# Altium schematic sheet 1-Controller.SchDoc(1-Controller)
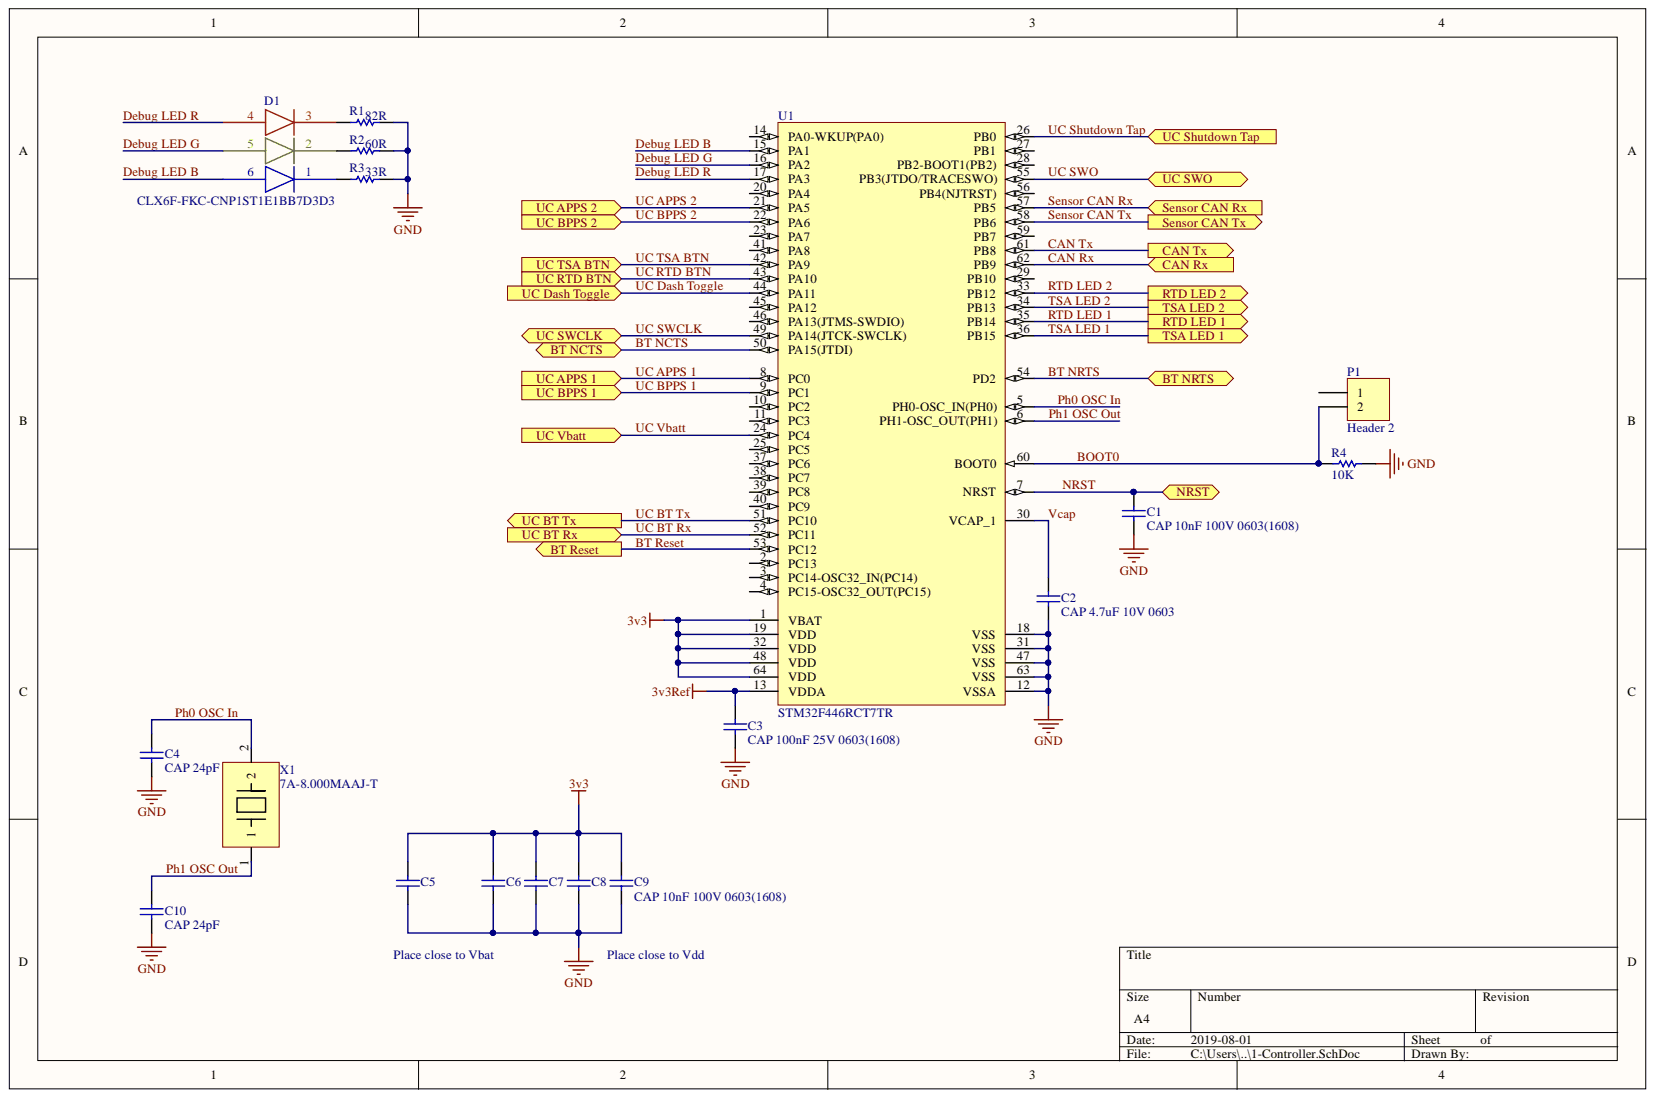
[diagram p0: 1-Controller.SchDoc]

## Parts
C1  CAP 10nF 100V 0603(1608)  value 10nF  [CAPC0603(1608)100_M]
C2  CAP 4.7uF 10V 0603  value 4.7uF  [CAPC0603(1608)100_M]
C3  CAP 100nF 25V 0603(1608)  value 100nF  [CAPC0603(1608)100_N]
C4  CAP 24pF  value 24pF  [CAPC0603(1608)100_N]
C5  CAP 10nF 100V 0603(1608)  [CAPC0603(1608)100_M]
C6  CAP 10nF 100V 0603(1608)  [CAPC0603(1608)100_M]
C7  CAP 10nF 100V 0603(1608)  [CAPC0603(1608)100_M]
C8  CAP 10nF 100V 0603(1608)  [CAPC0603(1608)100_M]
C9  CAP 10nF 100V 0603(1608)  value 10nF  [CAPC0603(1608)100_M]
C10  CAP 24pF  value 24pF  [CAPC0603(1608)100_N]
D1  CLX6F-FKC-CNP1ST1E1BB7D3D3  [CLX6C-FKB]
P1  Header 2  [HDR1X2]
R1  82R  [RESC0603(1608)_N]
R2  60R  [RESC0603(1608)_N]
R3  33R  [RESC0603(1608)_N]
R4  10K  [RESC0603(1608)_N]
U1  STM32F446RCT7TR  [STM-LQFP64_L]
X1  7A-8.000MAAJ-T  [2-SMD, No Lead]

## Ports
BT NCTS, BT NRTS, BT Reset, CAN Rx, CAN Tx, NRST, RTD LED 1, RTD LED 2, Sensor CAN Rx, Sensor CAN Tx, TSA LED 1, TSA LED 2, UC APPS 1, UC APPS 2, UC BPPS 1, UC BPPS 2, UC BT Rx, UC BT Tx, UC Dash Toggle, UC RTD BTN, UC Shutdown Tap, UC SWCLK, UC SWO, UC TSA BTN, UC Vbatt

## Nets
3v3: C5.2 C6.2 C7.2 C8.2 C9.2 U1.1(VBAT) U1.19(VDD) U1.32(VDD) U1.48(VDD) U1.64(VDD)
3v3Ref: C3.2 U1.13(VDDA)
GND: C1.1 C2.1 C3.1 C4.1 C5.1 C6.1 C7.1 C8.1 C9.1 C10.1 R1.2 R2.2 R3.2 R4.2 U1.12(VSSA) U1.18(VSS) U1.31(VSS) U1.47(VSS) U1.63(VSS)
BOOT0: P1.2 R4.1 U1.60(BOOT0)
BT NCTS: U1.50(PA15(JTDI)) ; port BT NCTS
BT NRTS: U1.54(PD2) ; port BT NRTS
BT Reset: U1.53(PC12) ; port BT Reset
CAN Rx: U1.62(PB9) ; port CAN Rx
CAN Tx: U1.61(PB8) ; port CAN Tx
Debug LED B: D1.6 U1.15(PA1)
Debug LED G: D1.5 U1.16(PA2)
Debug LED R: D1.4 U1.17(PA3)
NRST: C1.2 U1.7(NRST) ; port NRST
Ph0 OSC In: C4.2 U1.5(PH0-OSC_IN(PH0)) X1.2
Ph1 OSC Out: C10.2 U1.6(PH1-OSC_OUT(PH1)) X1.1
RTD LED 1: U1.35(PB14) ; port RTD LED 1
RTD LED 2: U1.33(PB12) ; port RTD LED 2
Sensor CAN Rx: U1.57(PB5) ; port Sensor CAN Rx
Sensor CAN Tx: U1.58(PB6) ; port Sensor CAN Tx
TSA LED 1: U1.36(PB15) ; port TSA LED 1
TSA LED 2: U1.34(PB13) ; port TSA LED 2
UC APPS 1: U1.8(PC0) ; port UC APPS 1
UC APPS 2: U1.21(PA5) ; port UC APPS 2
UC BPPS 1: U1.9(PC1) ; port UC BPPS 1
UC BPPS 2: U1.22(PA6) ; port UC BPPS 2
UC BT Rx: U1.52(PC11) ; port UC BT Rx
UC BT Tx: U1.51(PC10) ; port UC BT Tx
UC Dash Toggle: U1.44(PA11) ; port UC Dash Toggle
UC RTD BTN: U1.43(PA10) ; port UC RTD BTN
UC Shutdown Tap: U1.26(PB0) ; port UC Shutdown Tap
UC SWCLK: U1.49(PA14(JTCK-SWCLK)) ; port UC SWCLK
UC SWO: U1.55(PB3(JTDO/TRACESWO)) ; port UC SWO
UC TSA BTN: U1.42(PA9) ; port UC TSA BTN
UC Vbatt: U1.24(PC4) ; port UC Vbatt
Vcap: C2.2 U1.30(VCAP_1)
NetD1_1: D1.1 R3.1
NetD1_2: D1.2 R2.1
NetD1_3: D1.3 R1.1

Not connected: P1 1; U1 2(PC13) 3(PC14-OSC32_IN(PC14)) 4(PC15-OSC32_OUT(PC15)) 10(PC2) 11(PC3) 14(PA0-WKUP(PA0)) 20(PA4) 23(PA7) 25(PC5) 27(PB1) 28(PB2-BOOT1(PB2)) 29(PB10) 37(PC6) 38(PC7) 39(PC8) 40(PC9) 41(PA8) 45(PA12) 46(PA13(JTMS-SWDIO)) 56(PB4(NJTRST)) 59(PB7)

## Library data of the parts
C1 C5-C9 = CMP-1035-02326-1: CAP 10nF 100V ±20% 0603 (1608 Metric) Thickness 1mm SMD; Tolerance: Â±20%; Case-Metric: 1608; Case-EIA: 0603; Technology: SMT; Rated Voltage: 100 V; Max Thickness: 1 mm; Library Name: Altium Content Vault; Component Kind: Standard
  C5-C8: Value: 10nF
C2 = CMP-1035-02787-1: CAP 4.7uF 10V ±20% 0603 (1608 Metric) Thickness 1mm SMD; Tolerance: Â±20%; Case-Metric: 1608; Case-EIA: 0603; Technology: SMT; Rated Voltage: 10 V; Max Thickness: 1 mm; Library Name: Altium Content Vault; Component Kind: Standard
C3 = CMP-1035-02589-1: CAP 100nF 25V ±20% 0603 (1608 Metric) Thickness 1mm SMD; Tolerance: Â±20%; Case-Metric: 1608; Case-EIA: 0603; Technology: SMT; Rated Voltage: 25 V; Max Thickness: 1 mm; Library Name: Altium Content Vault; Component Kind: Standard
C4 C10 = CMP-1035-00843-1: CAP 24pF 16V ±2% 0603 (1608 Metric) Thickness 1mm SMD; Tolerance: Â±2%; Case-Metric: 1608; Case-EIA: 0603; Technology: SMT; Rated Voltage: 16 V; Max Thickness: 1 mm; Library Name: Altium Content Vault; Component Kind: Standard
  C4: Value: 24pF
D1 = LED - CLX6F-FKC-CNP1ST1E1BB7D3D3: Red, Green, Blue (RGB) 622nm Red, 530nm Green, 470nm Blue LED Indication - Discrete 2.1V Red, 2.7V Green, 3V Blue 6-SMD, J-Lead; ComponentLink1URL: https://www.digikey.ca/product-detail/en/cree-inc/CLX6F-FKC-CNP1ST1E1BB7D3D3/CLX6F-FKC-CNP1ST1E1BB7D3D3CT-ND/6362814; ComponentLink1Description: Digikey; Component Kind: Standard; Library Name: VCB_2019.SchLib
P1 = Header 2: Header, 2-Pin; Signal Integrity: Connector; Library Name: Miscellaneous Connectors.IntLib; LatestRevisionNote: Re-released for DXP Platform.; LatestRevisionDate: 17-Jul-2002; Component Kind: Standard; Publisher: Altium Limited
R1 = CMP-1012-00048-1: 82R 0.1W 5% 0603 (1608 Metric)  SMD; Value: 82.00 Ohm; Case-Metric: 1608; Case-EIA: 0603; Tolerance: 5 %; Technology: SMT; Power: 0.1 W; Library Name: Altium Content Vault; Component Kind: Standard
R2 = CMP-1012-00372-1: 60R4 0.1W 1% 0603 (1608 Metric)  SMD; Value: 60.40 Ohm; Case-Metric: 1608; Case-EIA: 0603; Tolerance: 1 %; Technology: SMT; Power: 0.1 W; Library Name: Altium Content Vault; Component Kind: Standard
R3 = CMP-1012-00038-1: 33R 0.1W 5% 0603 (1608 Metric)  SMD; Value: 33.00 Ohm; Case-Metric: 1608; Case-EIA: 0603; Tolerance: 5 %; Technology: SMT; Power: 0.1 W; Library Name: Altium Content Vault; Component Kind: Standard
R4 = CMP-1012-00098-1: 10K 0.1W 5% 0603 (1608 Metric)  SMD; Value: 10000.00 Ohm; Case-Metric: 1608; Case-EIA: 0603; Tolerance: 5 %; Technology: SMT; Power: 0.1 W; Library Name: Altium Content Vault; Component Kind: Standard
U1 = CMP-0243-00217-1: ARM Cortex-M4 32-bit MCU+FPU, 256 KB Flash, 128 KB Internal RAM, 50 I/Os, 64-Pin LQFP, -40 to 105 degC, Tape and Reel; Supply Voltage(Vcc) min (V): 1.7; Operating Frequency(F) (Processor speed) (MHz): 180; Internal RAM Size (kB): 128; I/Os (High Current): 50; FLASH Size (Prog) (kB): 256; D/A Converter: 2; Packing: Tape and Reel; Package Reference: 64-Pin Low-profile Quad Flat Package, Body 10 x 10 mm, Pitch 0.5 mm; Package Description: LQFP64; Mounting Technology: Surface Mount; Library Name: Altium Content Vault; DatasheetVersion: Rev. 6; Core: ARM Cortex-M4; ComponentLink2URL: http://www.st.com/st-web-ui/static/active/en/resource/technical/document/datasheet/DM00141306.pdf; ComponentLink2Description: Datasheet; ComponentLink1URL: http://www.st.com/; ComponentLink1Description: Manufacturer URL; Component Kind: Standard
X1 = 8MHz crystal, 7A-8.000MAAJ-T: Manufacturer: TXC CORPORATION; Operating Mode: Fundamental; Load Capacitance: 18pF; Frequency Tolerance: ±30ppm; Frequency: 8MHz; ESR (Equivalent Series Resistance): 80 Ohm; Type: MHz Crystal; Supplier Part Number 1: 887-1448-1-ND; Supplier 1: Digi-Key; Stock 1: 30692; Size / Dimension: 0.197" L x 0.126" W (5.00mm x 3.20mm); RoHS: RoHS Compliant; Ratings: -; Pricing 1: 1=1.12, 10=0.989, 50=0.9234, 100=0.8162, 500=0.77322 (CAD); Part Status: Active; Packaging: Cut Tape (CT); Package / Case: 2-SMD, No Lead; Operating Temperature: -20°C ~ 70°C; Mounting Type: Surface Mount; Manufacturer Part Number: 7A-8.000MAAJ-T; Height - Seated (Max): 0.053" (1.35mm); Frequency Stability: ±30ppm; ComponentLink1URL: http://www.txccrystal.com/images/pdf/7a.pdf; ComponentLink1Description: http://www.txccrystal.com/images/pdf/7a.pdf; Component Kind: Standard; Category: Crystals, Oscillators, Resonators; Library Name: VCU Library.SchLib
